# Altium schematic sheet Logic_Protection.SchDoc
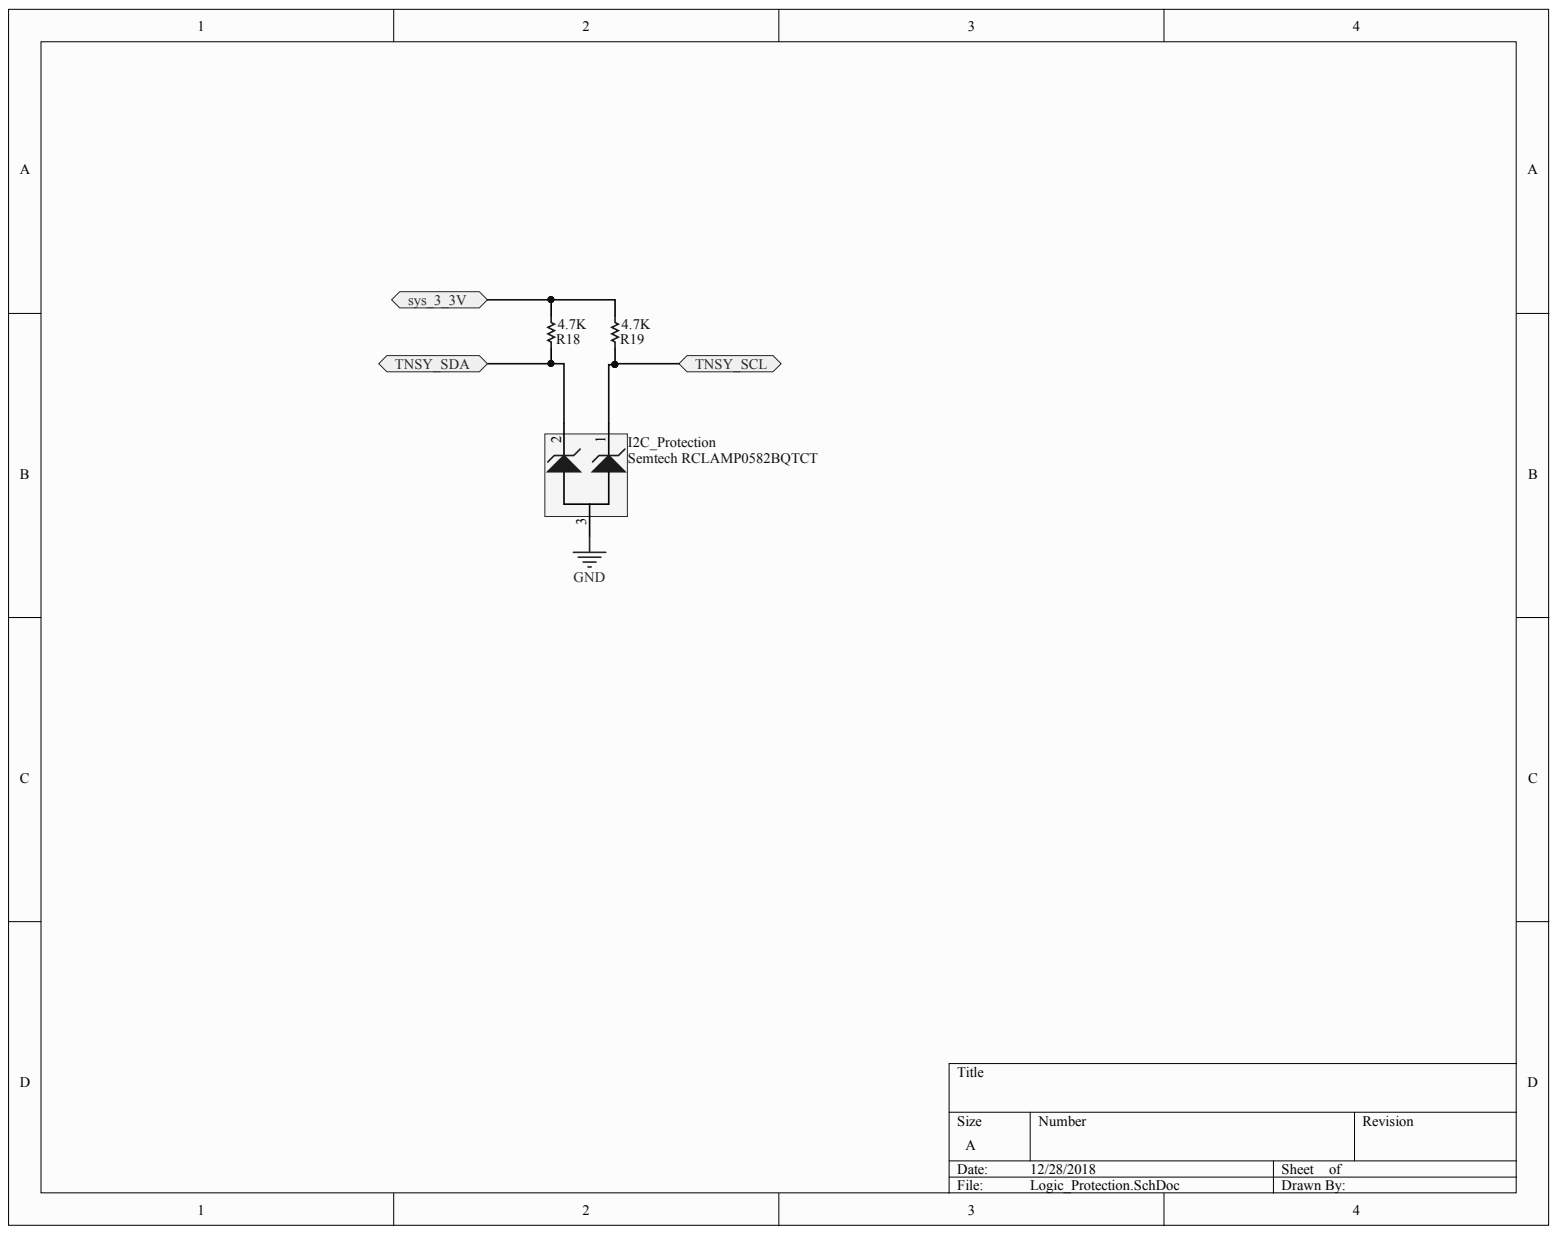
[diagram p0: Logic_Protection.SchDoc]

## Parts
I2C_Protection  Semtech RCLAMP0582BQTCT  [SOP3]
R18  Resistance: 4.7K  [PCB-xnm56hls5we6bt40qwox-1]  (hidden comment: SYM-fea435dd441a2163-1)
R19  Resistance: 4.7K  [PCB-xnm56hls5we6bt40qwox-1]  (hidden comment: SYM-fea435dd441a2163-1)

## Ports
SYS_3_3V, TNSY_SCL, TNSY_SDA

## Nets
GND: I2C_Protection.3
SYS_3_3V: R18.2 R19.2 ; port SYS_3_3V
TNSY_SCL: I2C_Protection.1 R19.1 ; port TNSY_SCL
TNSY_SDA: I2C_Protection.2 R18.1 ; port TNSY_SDA

## Library data of the parts
I2C_Protection = CMP-0f6bc71064c9d0ac-1: Diode TVS Dual Uni-Directional 5V 300W 3-Pin SOT-523 T/R; Breakdown Voltage: 11.0 V (max); Lead-Free Status: Lead Free; Component Kind: Standard; Library Name: FavoritesLibrary; Manufacturer Part Number: RCLAMP0582BQTCT; Number of Pins: 3; OctopartID: 0f6bc71064c9d0ac; Octopart URL: https://octopart.com/rclamp0582bqtct-semtech-24202948; Packaging: Tape & Reel (TR), Cut Tape (CT); RoHS: Compliant
R18 R19 = CMP-fea435dd441a2163-1: R Series Axial Through Hole Fixed Resistor 10Ohms +/-0.1% 0.25W +/-15ppm/degC; Manufacturer Part Number: YR1B10RCC; ELV Compliant: Compliant; Power Rating: 250 mW; Resistance: 10 Ω; Resistance Tolerance: ±0.1%; Voltage Rating (DC): 500 V; Component Kind: Standard; Library Name: CommunityVault; Number of Pins: 2; Packaging: Ammo Pack; RoHS: Compliant
